# Altium schematic sheet Relay Matrix and Power Supply.SchDoc
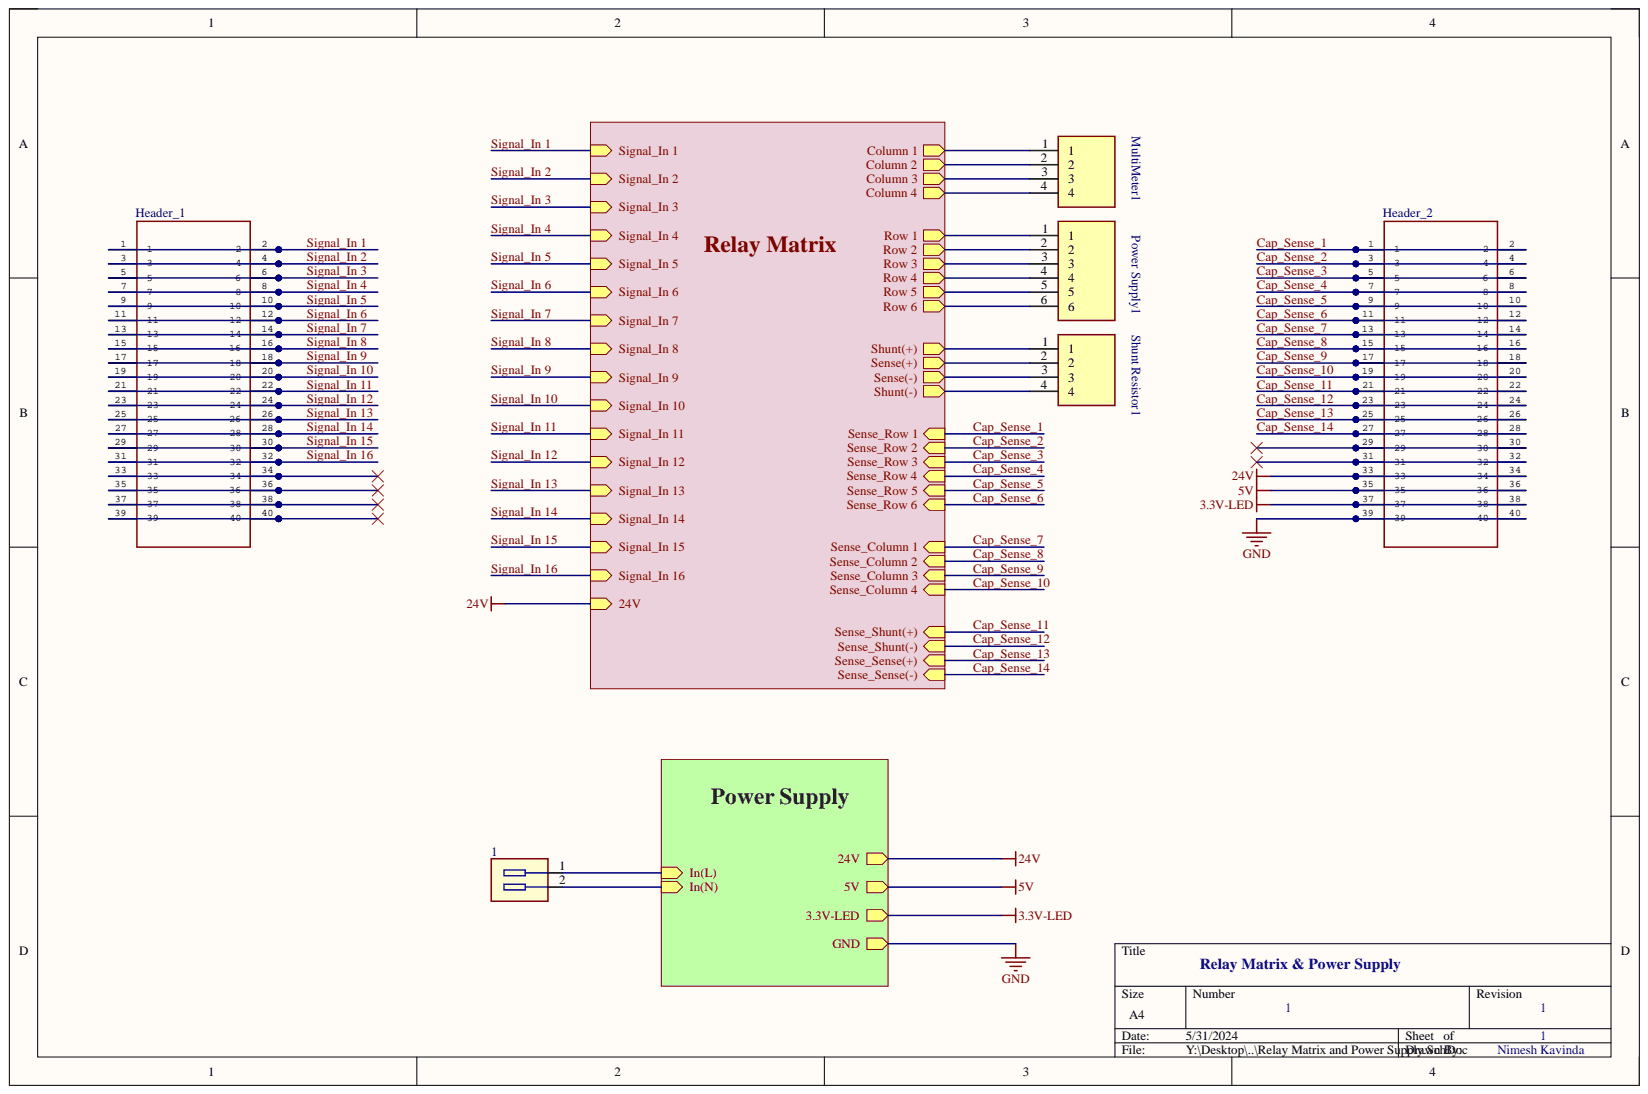
[diagram p0: Relay Matrix and Power Supply.SchDoc]

## Parts
1  MSTBVA2,5/2-G-5,08  [CON_5.08M_2P_PHC_MSTBVA]  (value not drawn)
Header_1  40Pin SMD Header  [40Pin SMD header]  (value not drawn)
Header_2  40Pin SMD Header  [40Pin SMD header]  (value not drawn)
MultiMeter1  Barrier Connector - 4Pin  [Barrier Connector - 4Pin]  (value not drawn)
Power_Supply1  Barrier Connector -6Pin  [Barrier Connector - 6Pin]  (value not drawn)
Shunt_Resistor1  Barrier Connector - 4Pin  [Barrier Connector - 4Pin]  (value not drawn)

## Nets
3.3V-LED: Header_2.37 Header_2.38
5V: Header_2.35 Header_2.36
24V: Header_2.33 Header_2.34
GND: Header_2.39 Header_2.40
Cap_Sense_1: Header_2.1 Header_2.2
Cap_Sense_2: Header_2.3 Header_2.4
Cap_Sense_3: Header_2.5 Header_2.6
Cap_Sense_4: Header_2.7 Header_2.8
Cap_Sense_5: Header_2.9 Header_2.10
Cap_Sense_6: Header_2.11 Header_2.12
Cap_Sense_7: Header_2.13 Header_2.14
Cap_Sense_8: Header_2.15 Header_2.16
Cap_Sense_9: Header_2.17 Header_2.18
Cap_Sense_10: Header_2.19 Header_2.20
Cap_Sense_11: Header_2.21 Header_2.22
Cap_Sense_12: Header_2.23 Header_2.24
Cap_Sense_13: Header_2.25 Header_2.26
Cap_Sense_14: Header_2.27 Header_2.28
Signal_In 1: Header_1.1 Header_1.2
Signal_In 2: Header_1.3 Header_1.4
Signal_In 3: Header_1.5 Header_1.6
Signal_In 4: Header_1.7 Header_1.8
Signal_In 5: Header_1.9 Header_1.10
Signal_In 6: Header_1.11 Header_1.12
Signal_In 7: Header_1.13 Header_1.14
Signal_In 8: Header_1.15 Header_1.16
Signal_In 9: Header_1.17 Header_1.18
Signal_In 10: Header_1.19 Header_1.20
Signal_In 11: Header_1.21 Header_1.22
Signal_In 12: Header_1.23 Header_1.24
Signal_In 13: Header_1.25 Header_1.26
Signal_In 14: Header_1.27 Header_1.28
Signal_In 15: Header_1.29 Header_1.30
Signal_In 16: Header_1.31 Header_1.32
Net1_1: 1.1
Net1_2: 1.2
NetHeader_1_33: Header_1.33 Header_1.34
NetHeader_1_35: Header_1.35 Header_1.36
NetHeader_1_37: Header_1.37 Header_1.38
NetHeader_1_39: Header_1.39 Header_1.40
NetHeader_2_29: Header_2.29 Header_2.30
NetHeader_2_31: Header_2.31 Header_2.32
NetMultiMeter1_1: MultiMeter1.1
NetMultiMeter1_2: MultiMeter1.2
NetMultiMeter1_3: MultiMeter1.3
NetMultiMeter1_4: MultiMeter1.4
NetPower Supply1_1: Power_Supply1.1
NetPower Supply1_2: Power_Supply1.2
NetPower Supply1_3: Power_Supply1.3
NetPower Supply1_4: Power_Supply1.4
NetPower Supply1_5: Power_Supply1.5
NetPower Supply1_6: Power_Supply1.6
NetShunt Resistor1_1: Shunt_Resistor1.1
NetShunt Resistor1_2: Shunt_Resistor1.2
NetShunt Resistor1_3: Shunt_Resistor1.3
NetShunt Resistor1_4: Shunt_Resistor1.4

## Library data of the parts
1 = 60000074: T_ CON M ST ____ ___2P _1RO Sn R5.08 GRN; Manufacturer: Phoenix Contact; ManufacturerPartNo: MSTBVA2,5/2-G-5,08 (1755736); VariosystemsPartNo: 201528; Database Table Name: Connector_VS; StateFootprint: 1; System: Connector; VsAvgPrice: 0.226443; VsChStock: 2335; VsStock: 17144; AlternatePart: N/A; Component Kind: Standard; Library Name: VsLibrary.SVNDBLib; LibraryID: 60000074; PartGender: M; PartPitch: R5.08; PartValue: MSTBVA2,5/2-G-5,08; StateParameters: 1; StateSchematicSymbol: 1
Header_1 Header_2 = 40Pin SMD Header: Component Kind: Standard; Library Name: 40Pin SMD Header.SchLib
MultiMeter1 Shunt_Resistor1 = Barrier Connector - 4Pin: Component Kind: Standard; Library Name: Barrier Connector - 4Pin.SchLib
Power_Supply1 = Barrier Connector -6Pin: Component Kind: Standard; Library Name: Barrier Connector - 6Pin.SchLib
